# Altium schematic sheet 00_main.SchDoc
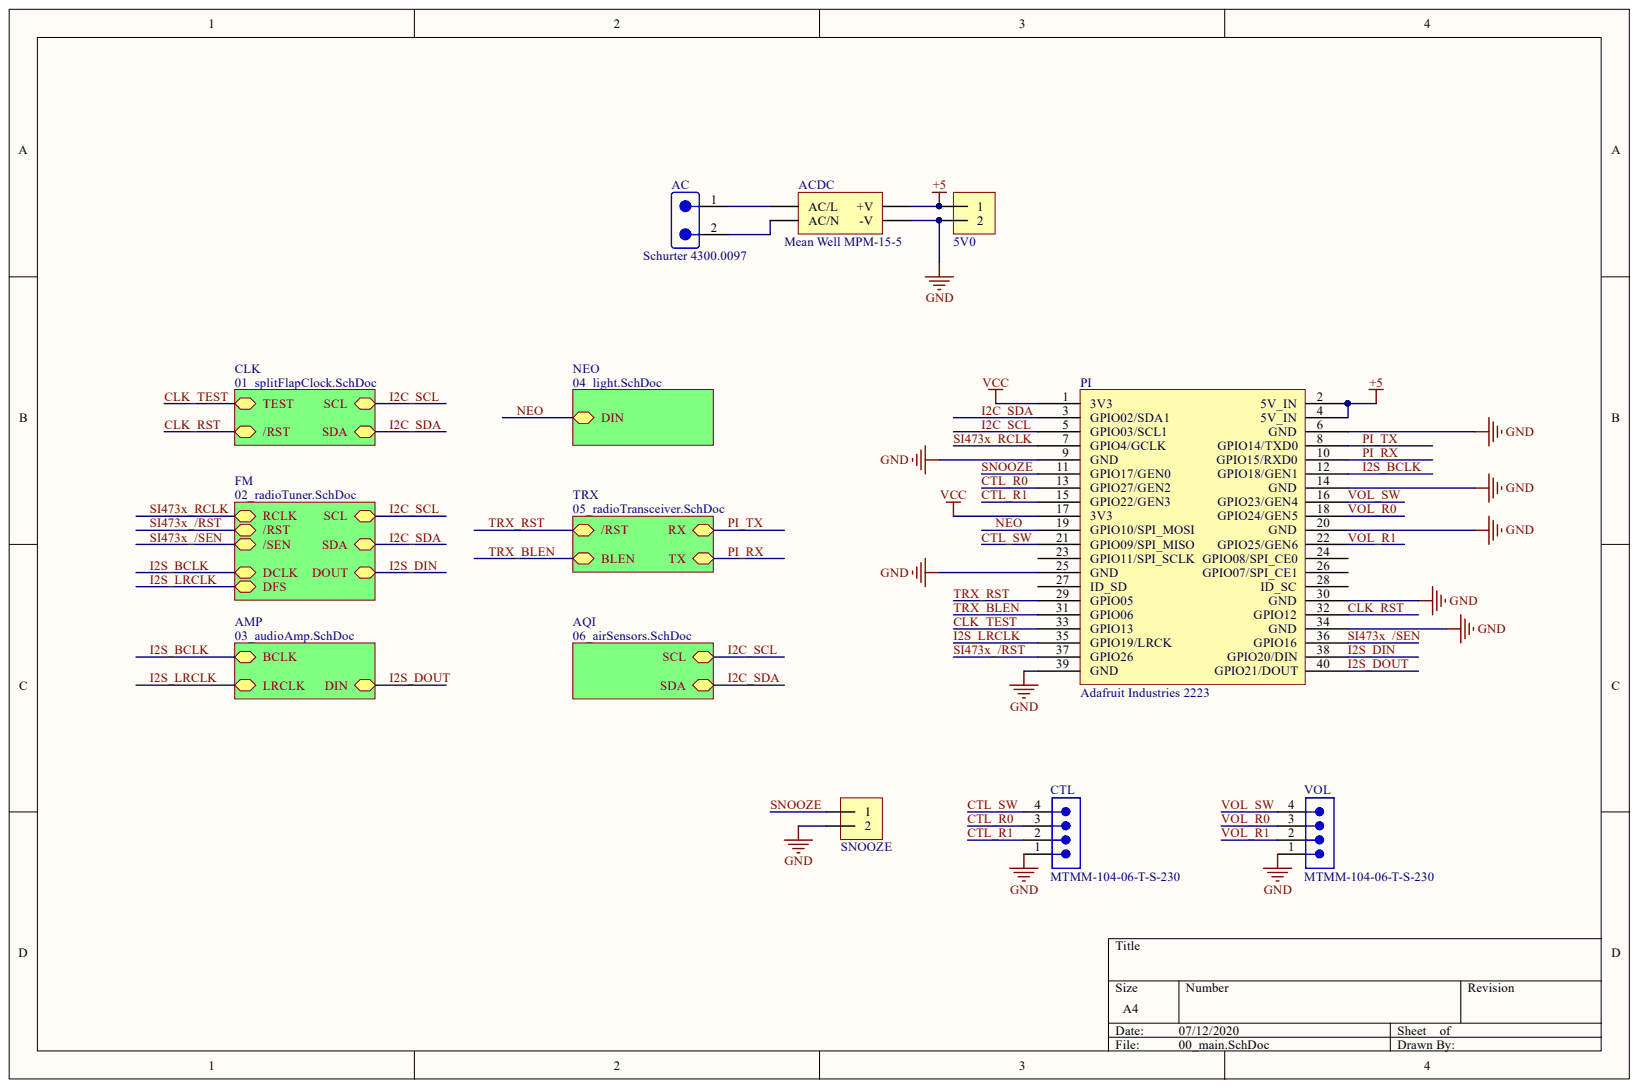
[diagram p0: 00_main.SchDoc]

## Parts
5V0  DF3-2P-2DS  [PCB-mpj3bssdo6wbgooiwqfm-1]  (value not drawn)
AC  Schurter 4300.0097  Manufacturer Part Number: 4300.0097  [PCB-c5q3x77i7sh8cgn94xen-1]
ACDC  Mean Well MPM-15-5  Manufacturer Part Number: MPM-15-5  [PCB-10hrdzkpmyybdog0ir3t-1]
CTL  MTMM-104-06-T-S-230  [SMTC-MTMM-104-06-X-S-230]
PI  Adafruit Industries 2223  [PCB-dv9vmhrfa2chsb9gidwm-1]
SNOOZE  DF3-2P-2DS  [PCB-mpj3bssdo6wbgooiwqfm-1]  (value not drawn)
VOL  MTMM-104-06-T-S-230  [SMTC-MTMM-104-06-X-S-230]

## Nets
+5: 5V0.1 ACDC.+V PI.2(5V_IN) PI.4(5V_IN)
GND: 5V0.2 ACDC.-V CTL.1 PI.6(GND) PI.9(GND) PI.14(GND) PI.20(GND) PI.25(GND) PI.30(GND) PI.34(GND) PI.39(GND) SNOOZE.2 VOL.1
VCC: PI.1(3V3) PI.17(3V3)
CLK_RST: PI.32(GPIO12)
CLK_TEST: PI.33(GPIO13)
CTL_R0: CTL.3 PI.13(GPIO27/GEN2)
CTL_R1: CTL.2 PI.15(GPIO22/GEN3)
CTL_SW: CTL.4 PI.21(GPIO09/SPI_MISO)
I2C_SCL: PI.5(GPIO03/SCL1)
I2C_SDA: PI.3(GPIO02/SDA1)
I2S_BCLK: PI.12(GPIO18/GEN1)
I2S_DIN: PI.38(GPIO20/DIN)
I2S_DOUT: PI.40(GPIO21/DOUT)
I2S_LRCLK: PI.35(GPIO19/LRCK)
NEO: PI.19(GPIO10/SPI_MOSI)
PI_RX: PI.10(GPIO15/RXD0)
PI_TX: PI.8(GPIO14/TXD0)
SI473X_/RST: PI.37(GPIO26)
SI473X_/SEN: PI.36(GPIO16)
SI473X_RCLK: PI.7(GPIO4/GCLK)
SNOOZE: PI.11(GPIO17/GEN0) SNOOZE.1
TRX_BLEN: PI.31(GPIO06)
TRX_RST: PI.29(GPIO05)
VOL_R0: PI.18(GPIO24/GEN5) VOL.3
VOL_R1: PI.22(GPIO25/GEN6) VOL.2
VOL_SW: PI.16(GPIO23/GEN4) VOL.4
NetAC_1: AC.1 ACDC.AC/L
NetAC_2: AC.2 ACDC.AC/N
NetPI_23: PI.23(GPIO11/SPI_SCLK)
NetPI_24: PI.24(GPIO08/SPI_CE0)
NetPI_26: PI.26(GPIO07/SPI_CE1)
NetPI_27: PI.27(ID_SD)
NetPI_28: PI.28(ID_SC)

## Library data of the parts
5V0 SNOOZE = CMP-f4783dcb9f562bbf-3: Conn Unshrouded Header HDR 2 POS 2mm Solder ST Thru-Hole; Component Kind: Standard; Library Name: CommunityVault
AC = CMP-85ef4ce7b7505a28-1: Appliance Inlet; 250VAC; 2.5A; Frnt Panel Mt-Screw; PCB Terminals; Thermoplastic; IP40; Component Kind: Standard; Library Name: CommunityVault; Octopart URL: http://octopart.com/4300.0097-schurter-137177
ACDC = CMP-e02a2c3d5fe73fed-2: MPM-15 Series 15 W 5 V Single Output Green Medical Encapsulated Power Supply; Lead-Free Status: Lead Free; Component Kind: Standard; Library Name: FavoritesLibrary; Mounting Style: PCB; OctopartID: e02a2c3d5fe73fed; Octopart URL: https://octopart.com/mpm-15-5-mean+well-85826219; RoHS: Compliant
CTL VOL = CMP-6425482-2: Modified Through-Hole Header, Vertical, -55 to 105 degC, 2 mm Pitch, 4-Pin, Male, RoHS; Lead-Free Status: Lead Free; Component Kind: Standard; Contact Material: Phosphor Bronze; Library Name: CommunityVault; Manufacturer Part Number: MTMM-104-06-T-S-230; Mounting Style: Through Hole; Number of Rows: 1; OctopartID: 93705b68eb1a4cb7; Octopart Url: https://octopart.com/mtmm-104-06-t-s-230-samtec-17980684; RoHS: Compliant
PI = CMP-4e918466a73d9156-23: GPIO Stacking Header for Pi A+/B+/Pi 2/Pi 3 - Extra-long 2x20 Pins; Component Kind: Standard; Library Name: CommunityVault
